# Altium schematic sheet Skeem1.SchDoc(Skeem1)
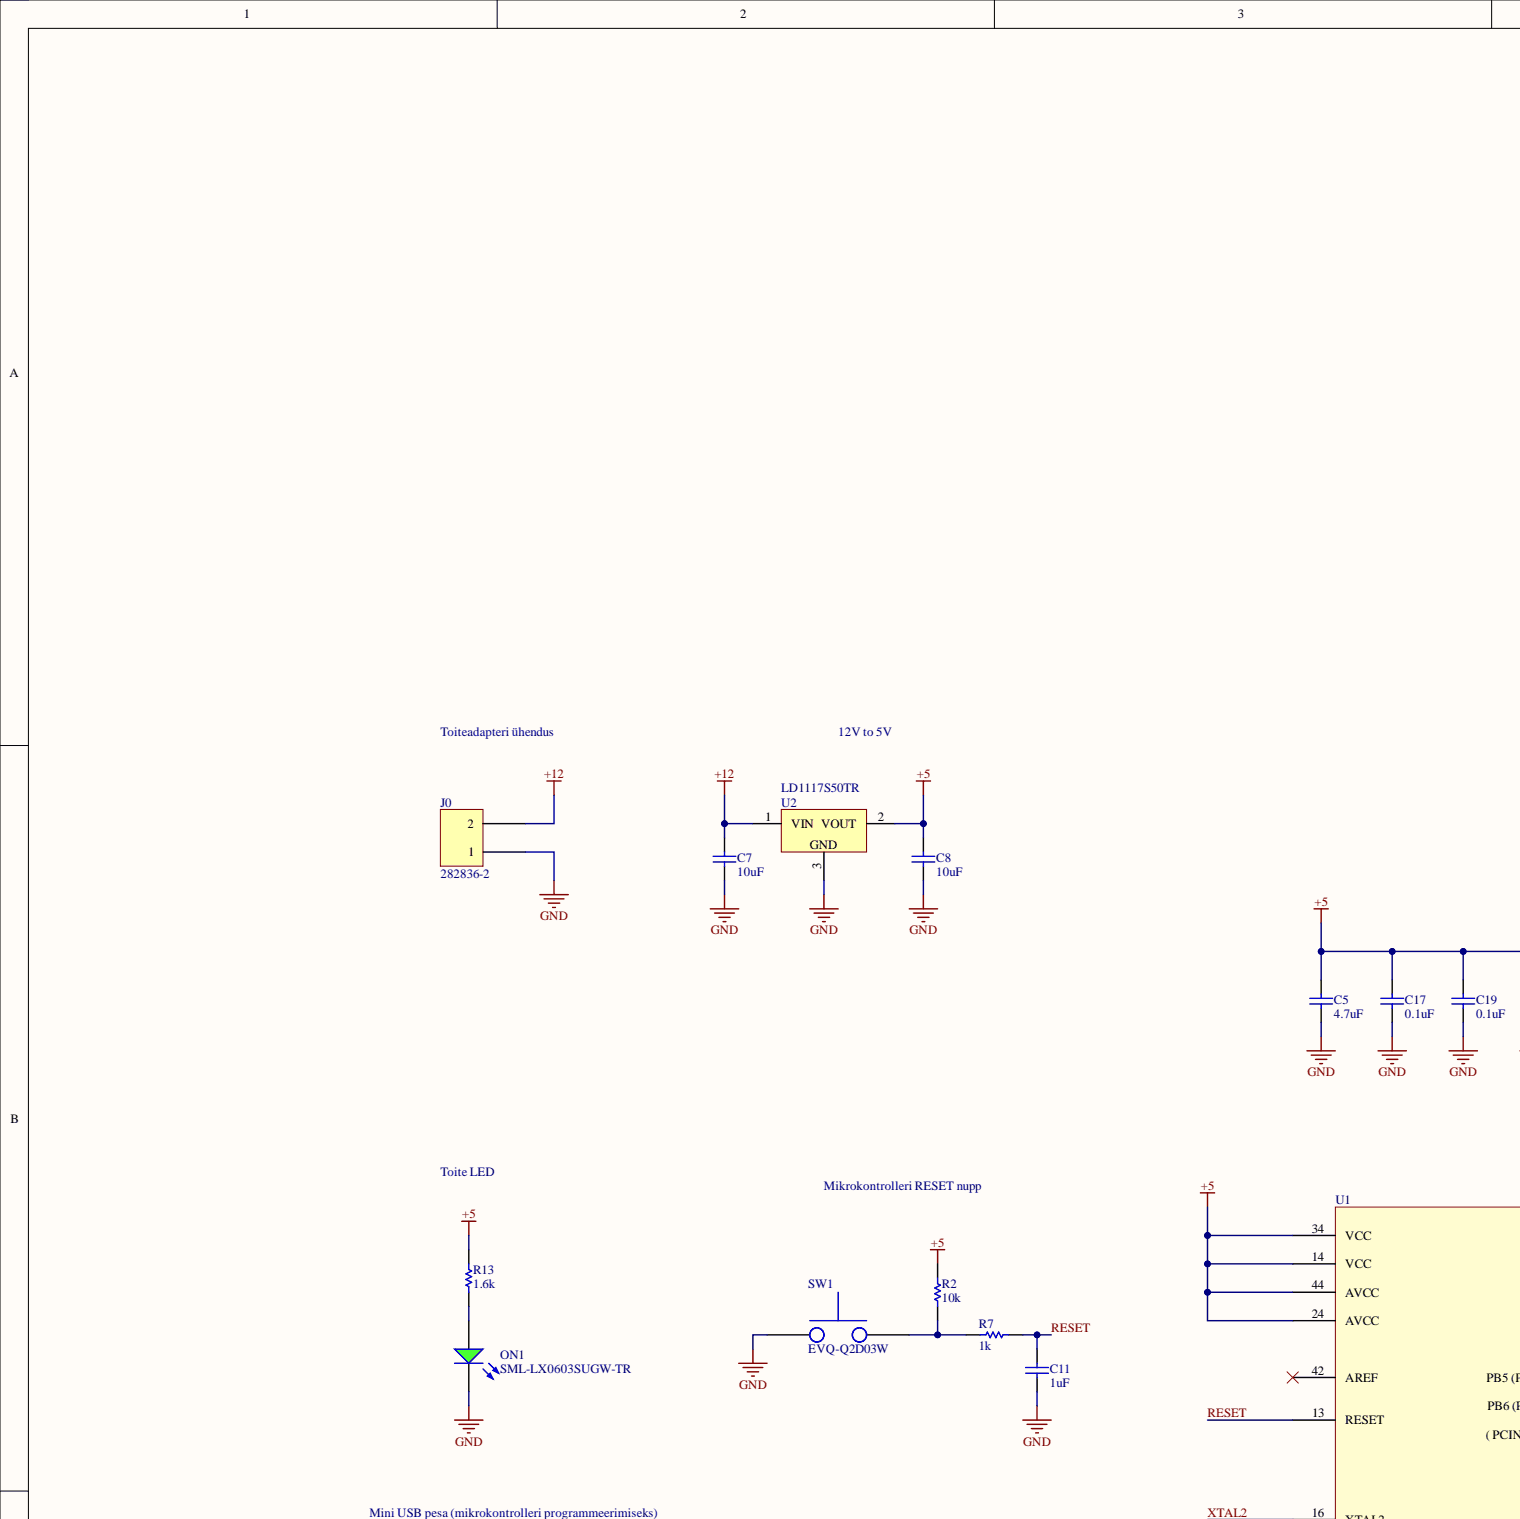
[diagram p0: Skeem1.SchDoc, top left]
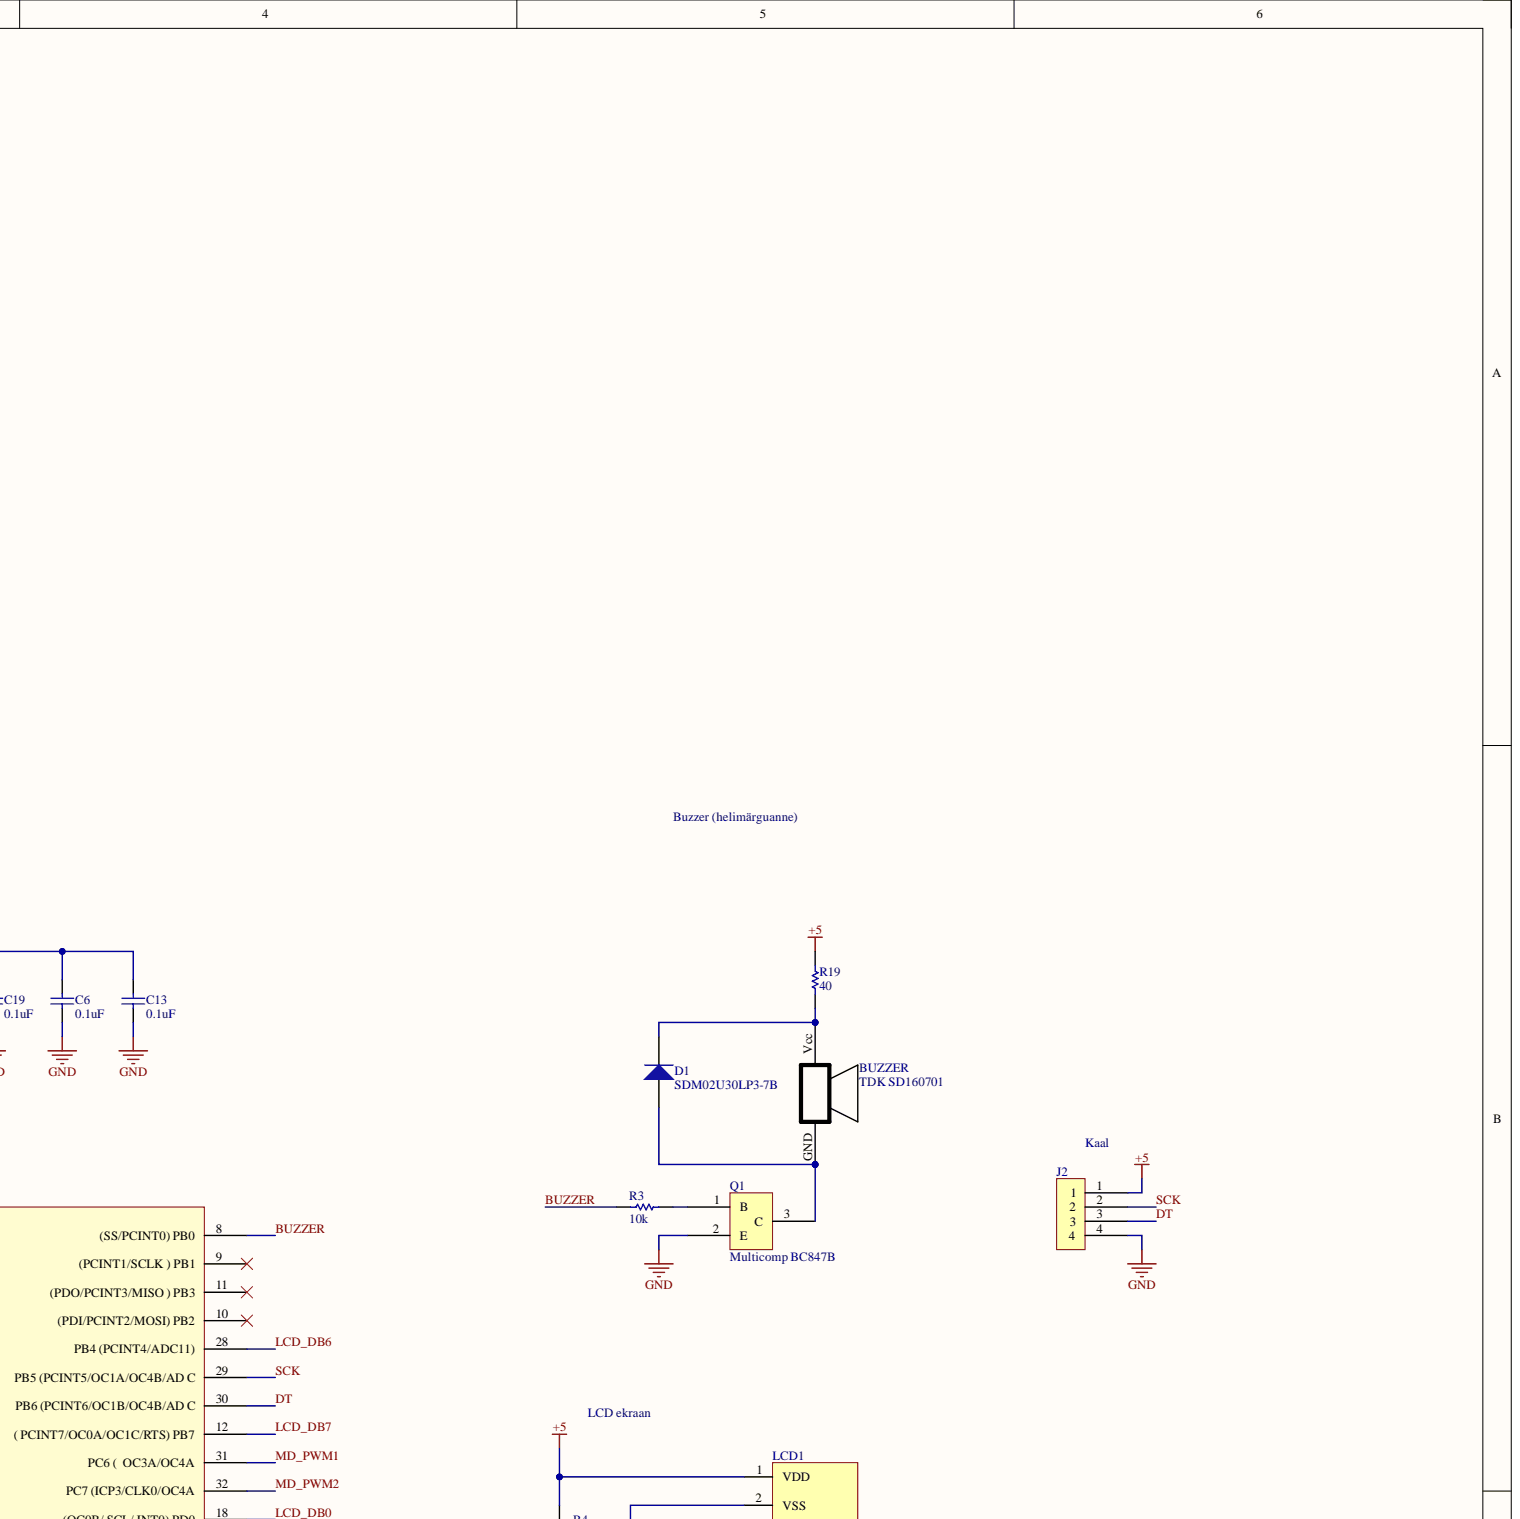
[diagram p1: Skeem1.SchDoc, top right]
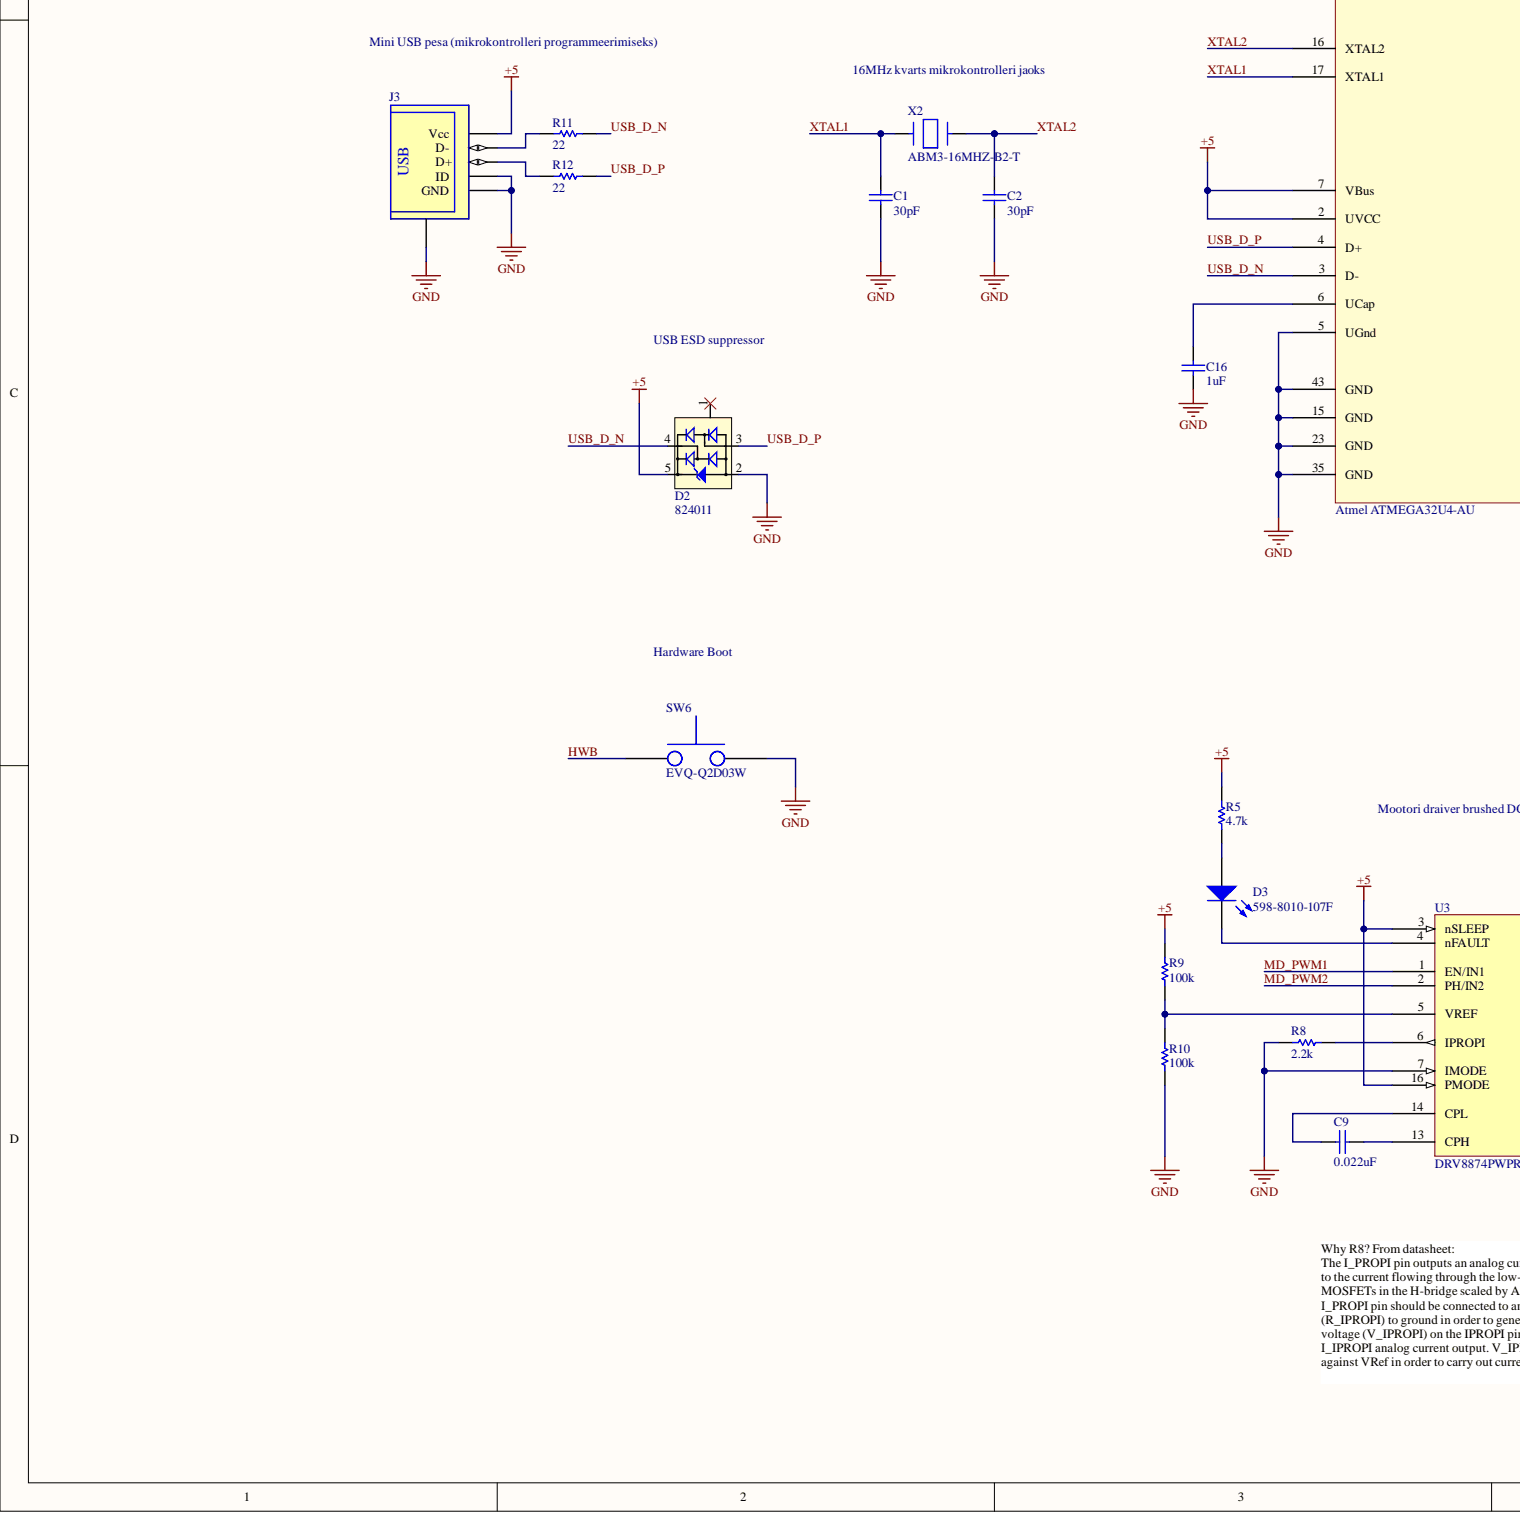
[diagram p2: Skeem1.SchDoc, bottom left]
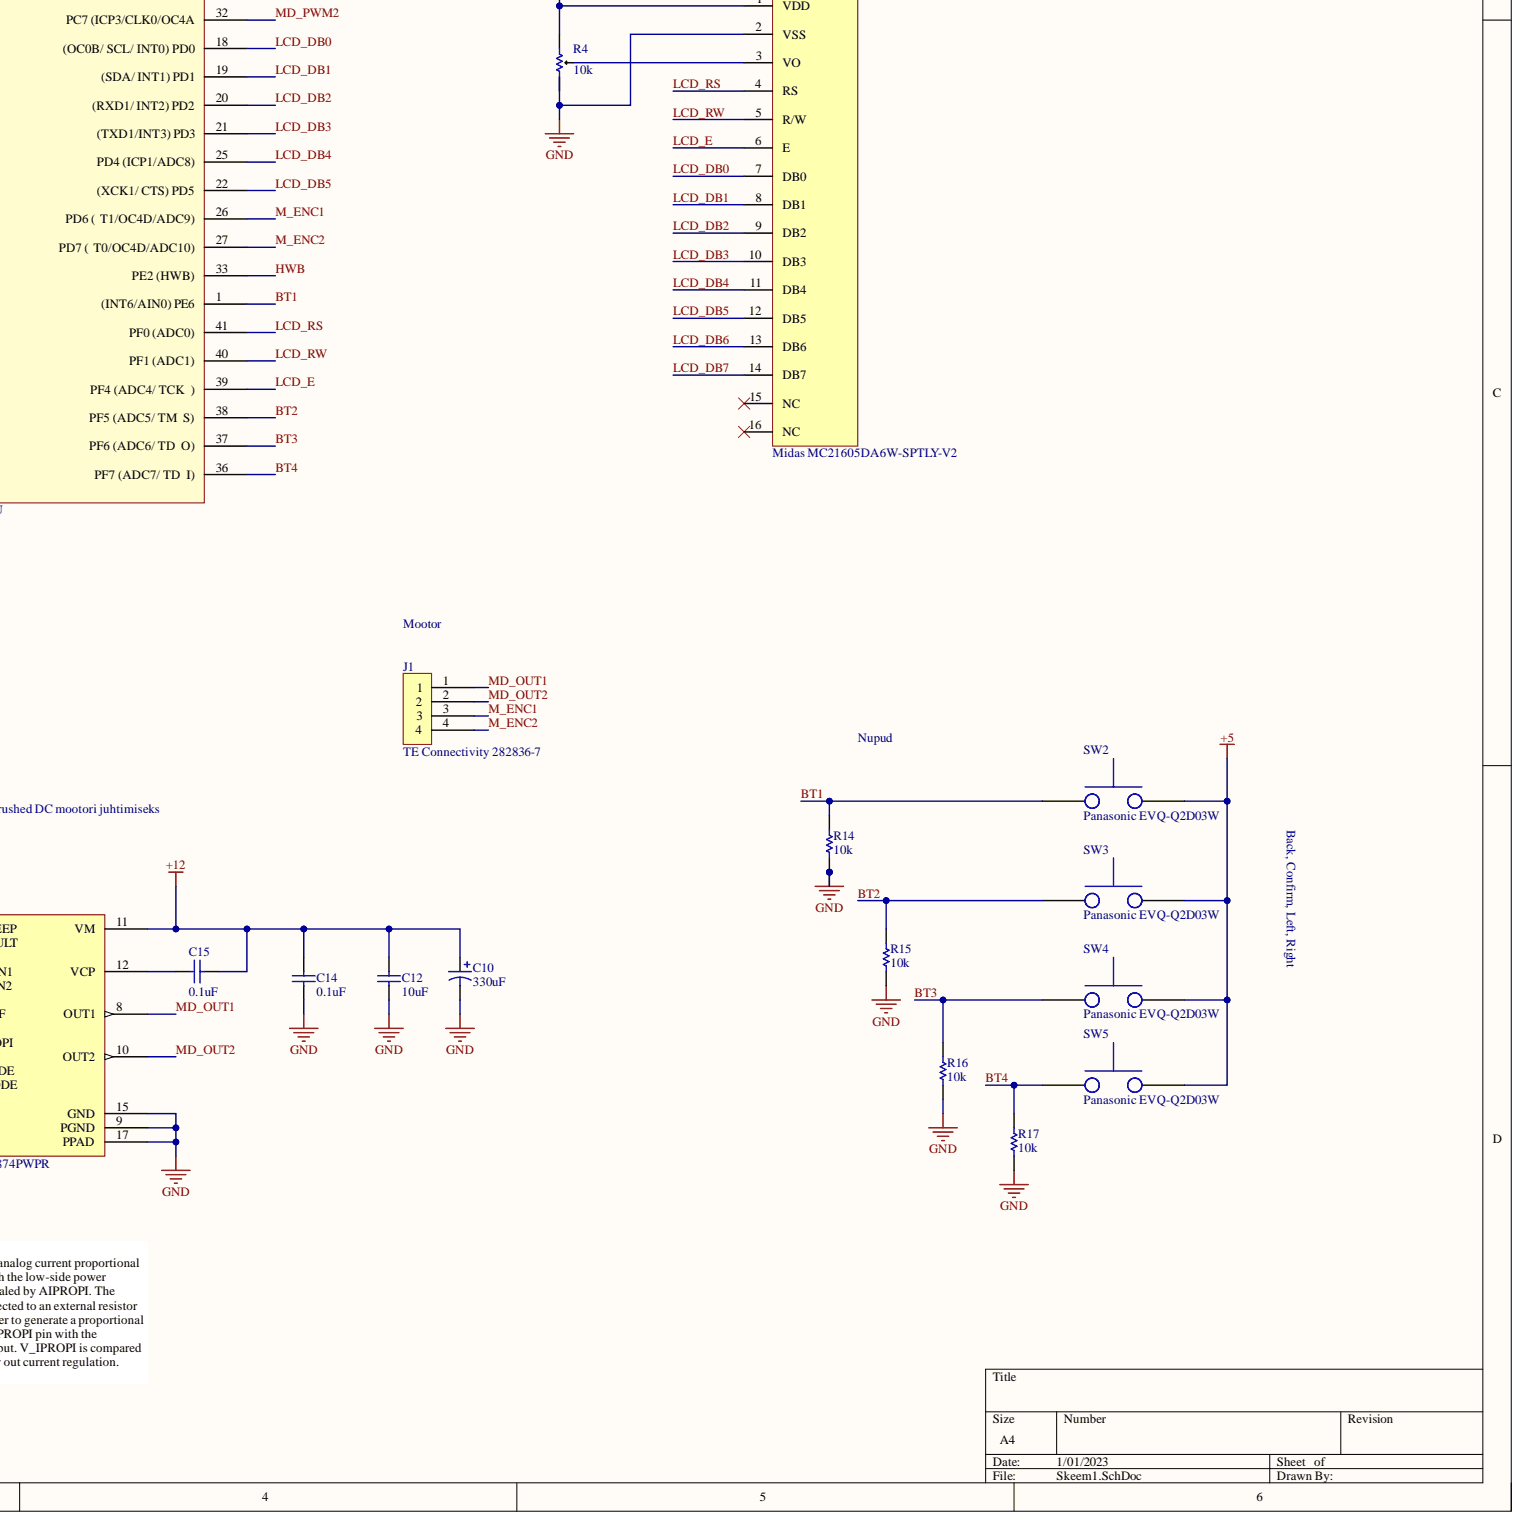
[diagram p3: Skeem1.SchDoc, bottom right]

## Parts
BUZZER  TDK SD160701  Manufacturer Part Number: SD160701
C1  30pF
C2  30pF
C5  4.7uF
C6  0.1uF  [PCB-0002-00062-3]
C7  10uF  [PCB-wvd42uoi0udsmgfukqhe-1]
C8  10uF  [PCB-wvd42uoi0udsmgfukqhe-1]
C9  0.022uF
C10  330uF  [PCB-8ijitsarb7x4oxse96cd-1]
C11  1uF  [PCB-zmhnirspvwu5lpu2azvo-1]
C12  10uF
C13  0.1uF  [PCB-0002-00062-3]
C14  0.1uF  [PCB-0002-00062-3]
C15  0.1uF  [PCB-0002-00062-3]
C16  1uF
C17  0.1uF  [PCB-0002-00062-3]
C19  0.1uF  [PCB-0002-00062-3]
D1  SDM02U30LP3-7B  [PCB-karmeiynjjwb0q118upo-1]
D2  824011
D3  598-8010-107F  [PCB-hueh31pu4k40hzp3j03i-1]
J0  282836-2
J1  TE Connectivity 282836-7  [PCB-3tvdnj0eouyeqqfalykp-1]
J2  TE Connectivity 282836-7  [PCB-3tvdnj0eouyeqqfalykp-1]
J3  MINI USB 2.0 TYPE B, RECEPTACLE, SMT (FCI 10033526-N3212MLF)  (value not drawn)
LCD1  Midas MC21605DA6W-SPTLY-V2  Manufacturer Part Number: MC21605DA6W-SPTLY-V2
ON1  SML-LX0603SUGW-TR  [PCB-0002-02151-1.A.4]
Q1  Multicomp BC847B  Manufacturer Part Number: BC847B  [PCB-3mljzpuay2uxg0ut9sma-1]
R2  10k  [PCB-0002-00066-3]
R3  10k  [PCB-0002-00066-3]
R4  10k  [PCB-obt52c5d0m95txgvi4qh-1]
R5  4.7k  [PCB-57p3ox399wggapangvi3-1]
R7  1k
R8  2.2k  [PCB-n8he3yyaps2a9usdbg2i-1]
R9  100k  [PCB-vit9mexsle3dy07yp330-1]
R10  100k  [PCB-vit9mexsle3dy07yp330-1]
R11  22
R12  22
R13  1.6k  [PCB-0002-00066-1]
R14  10k  [PCB-0002-00066-3]
R15  10k  [PCB-0002-00066-3]
R16  10k  [PCB-0002-00066-3]
R17  10k  [PCB-0002-00066-3]
R19  40
SW1  EVQ-Q2D03W  [PCB-5wv5hrqvibrfqodtaqn8-1]
SW2  Panasonic EVQ-Q2D03W  Manufacturer Part Number: EVQ-Q2D03W  [PCB-5wv5hrqvibrfqodtaqn8-1]
SW3  Panasonic EVQ-Q2D03W  Manufacturer Part Number: EVQ-Q2D03W  [PCB-5wv5hrqvibrfqodtaqn8-1]
SW4  Panasonic EVQ-Q2D03W  Manufacturer Part Number: EVQ-Q2D03W  [PCB-5wv5hrqvibrfqodtaqn8-1]
SW5  Panasonic EVQ-Q2D03W  Manufacturer Part Number: EVQ-Q2D03W  [PCB-5wv5hrqvibrfqodtaqn8-1]
SW6  EVQ-Q2D03W  [PCB-5wv5hrqvibrfqodtaqn8-1]
U1  Atmel ATMEGA32U4-AU  Manufacturer Part Number: ATMEGA32U4-AU
U2  LD1117S50TR  [PCB-0002-02299-1]
U3  DRV8874PWPR  [PCB-45171vetpwtrcknlr443-5]
X2  ABM3-16MHZ-B2-T

## Nets
+5: C5.2 C6.2 C8.2 C13.2 C17.2 C19.2 D2.5 J2.1 J3.1(Vcc) LCD1.1(VDD) R2.2 R4.3 R5.2 R9.1 R13.2 R19.2 SW2.1 SW3.1 SW4.1 SW5.1 U1.2(UVCC) U1.7(VBus) U1.14(VCC) U1.24(AVCC) U1.34(VCC) U1.44(AVCC) U2.2 U3.3(nSLEEP) U3.16(PMODE)
+12: C7.2 C10.1 C12.2 C14.2 C15.2 J0.2 U2.1 U3.11(VM)
GND: C1.1 C2.1 C5.1 C6.1 C7.1 C8.1 C10.2 C11.2 C12.1 C13.1 C14.1 C16.1 C17.1 C19.1 D2.2 J0.1 J2.4 J3.4(ID) J3.5(GND) J3.6 LCD1.2(VSS) ON1.C Q1.2 R4.1 R8.1 R10.2 R14.1 R15.1 R16.1 R17.1 SW1.2 SW6.1 U1.5(UGnd) U1.15(GND) U1.23(GND) U1.35(GND) U1.43(GND) U2.3 U3.7(IMODE) U3.9(PGND) U3.15(GND) U3.17(PPAD)
BT1: R14.2 SW2.2 U1.1((INT6/AIN0)_PE6)
BT2: R15.2 SW3.2 U1.38(S))
BT3: R16.2 SW4.2 U1.37(O))
BT4: R17.2 SW5.2 U1.36(I))
BUZZER: R3.1 U1.8((SS/PCINT0)_PB0)
DT: J2.3 U1.30(PB6_(PCINT6/OC1B/OC4B/AD_C)
HWB: SW6.2 U1.33(PE2_(HWB))
LCD_DB0: LCD1.7(DB0) U1.18((OC0B/_SCL/_INT0)_PD0)
LCD_DB1: LCD1.8(DB1) U1.19((SDA/_INT1)_PD1)
LCD_DB2: LCD1.9(DB2) U1.20((RXD1/_INT2)_PD2)
LCD_DB3: LCD1.10(DB3) U1.21((TXD1/INT3)_PD3)
LCD_DB4: LCD1.11(DB4) U1.25(PD4_(ICP1/ADC8))
LCD_DB5: LCD1.12(DB5) U1.22((XCK1/_CTS)_PD5)
LCD_DB6: LCD1.13(DB6) U1.28(PB4_(PCINT4/ADC11))
LCD_DB7: LCD1.14(DB7) U1.12((_PCINT7/OC0A/OC1C/RTS)_PB7)
LCD_E: LCD1.6(E) U1.39())
LCD_RS: LCD1.4(RS) U1.41(PF0_(ADC0))
LCD_RW: LCD1.5(R/W) U1.40(PF1_(ADC1))
M_ENC1: J1.3 U1.26(T1/OC4D/ADC9))
M_ENC2: J1.4 U1.27(T0/OC4D/ADC10))
MD_OUT1: J1.1 U3.8(OUT1)
MD_OUT2: J1.2 U3.10(OUT2)
MD_PWM1: U1.31(OC3A/OC4A) U3.1(EN/IN1)
MD_PWM2: U1.32(PC7_(ICP3/CLK0/OC4A) U3.2(PH/IN2)
RESET: C11.1 R7.2 U1.13(RESET)
SCK: J2.2 U1.29
USB_D_N: D2.4 R11.2 U1.3(D-)
USB_D_P: D2.3 R12.2 U1.4(D+)
XTAL1: C1.2 U1.17(XTAL1) X2.1
XTAL2: C2.2 U1.16(XTAL2) X2.2
NetBUZZER_GND: BUZZER.GND D1.1 Q1.3
NetBUZZER_Vcc: BUZZER.Vcc D1.2 R19.1
NetC9_1: C9.1 U3.14(CPL)
NetC9_2: C9.2 U3.13(CPH)
NetC15_1: C15.1 U3.12(VCP)
NetC16_2: C16.2 U1.6(UCap)
NetD3_A: D3.A R5.1
NetD3_K: D3.K U3.4(nFAULT)
NetJ3_2: J3.2(D-) R11.1
NetJ3_3: J3.3(D+) R12.1
NetLCD1_3: LCD1.3(VO) R4.2
NetON1_A: ON1.A R13.1
NetQ1_1: Q1.1 R3.2
NetR2_1: R2.1 R7.1 SW1.1
NetR8_2: R8.2 U3.6(IPROPI)
NetR9_2: R9.2 R10.1 U3.5(VREF)

Not connected: D2 1; LCD1 15(NC) 16(NC); U1 9((PCINT1/SCLK_)_PB1) 10((PDI/PCINT2/MOSI)_PB2) 11((PDO/PCINT3/MISO_)_PB3) 42(AREF)

## Library data of the parts
BUZZER = CMP-a5a96caf94997a6e-5: Audio Buzzer Electromagnetic 1Vo-p 5Vo-p 60mA 3Vo-p 80dBA Through Hole Pin Tray; Lead-Free Status: Lead Free; ReplaceComponentId: HENOFNDV; Resonant Frequency: 4.10 kHz; Voltage Rating (DC): 5.00 V; Component Kind: Standard; Library Name: My Personal Space; Mounting Style: Through Hole; Octopart Url: https://octopart.com/sd160701-tdk-791638; OctopartID: a5a96caf94997a6e; Packaging: Tray; PartId: 1; RoHS: Compliant
C1 C2 = CMP-3554665-2: Multilayer Ceramic Capacitors MLCC - SMD/SMT 0603 30pF 250V C0G 5% HI FREQ; Manufacturer Part Number: VJ0603D300JXPAJ; Capacitance: 3e-11 F; Dielectric Characteristic: C0G/NP0; Lead-Free Status: Lead Free; Voltage Rating (DC): 250.0 V; Capacitance Tolerance: ±5%; Component Kind: Standard; Library Name: My Personal Space; Octopart Url: https://octopart.com/vj0603d300jxpaj-vishay-45210262; OctopartID: a54f6ef45abf7a88; Packaging: Cut Tape (CT); RoHS: Compliant
C5 = CMP-4caf8516eb679368-5: CL10 Series 4.7 uF 10 V ±10 % Tolerance X5R SMT Multilayer Ceramic Capacitor; Manufacturer Part Number: CL10A475KP8NNNC; Capacitance: 4.7e-06 F; Capacitance Tolerance: ±10%; Lead-Free Status: Lead Free; Voltage Rating (DC): 10.0 V; Case/Package: 0603; Component Kind: Standard; Dielectric Characteristic: X5R; Library Name: My Personal Space; Mounting Style: Surface Mount; Octopart URL: http://octopart.com/cl10a475kp8nnnc-samsung-11764398; OctopartID: 4caf8516eb679368; RoHS: Compliant
C6 C13-C15 C17 C19 = CMP-3016f860c6a7efd1-1: CL10 Series 0.1 uF 100 V ±10 % Tolerance X7R SMT Multilayer Ceramic Capacitor; Manufacturer Part Number: CL10B104KC8NNNC; Capacitance: 100 nF; Capacitance Tolerance: ±10%; Lead-Free Status: Lead Free; Voltage Rating (DC): 100 V; Case/Package: 0603; Component Kind: Standard; Dielectric Characteristic: X7R; Library Name: My Personal Space; Octopart URL: http://octopart.com/cl10b104kc8nnnc-samsung-24957911; OctopartID: 3016f860c6a7efd1; RoHS: Compliant
C7 C8 = CMP-121611-5: C Series 0603 10 uF 25 V ±10 % Tolerance X5R Multilayer Ceramic Capacitor; Manufacturer: Murata; Part Number: GRM188R61E106KA73J; Ex Price: 0.36; Tolerance: 10%; VN Price: 850; Voltage/Wattage: 25V; Component Kind: Standard; Ex Supplier: https://www.mouser.vn/ProductDetail/Murata-Electronics/GRM188R61E106KA73J?qs=sGAEpiMZZMukHu%252BjC5l7YUSokBdqpA2iyRK88YQudCM%3D; Library Name: My Personal Space; OctopartID: a02668a768b37fe1; Package: 0603; Value: 10uF; VN Supplier: https://www.thegioiic.com/products/tu-gom-0603-10uf-25v
C9 = CMP-3551317-2: Multilayer Ceramic Capacitors MLCC - SMD/SMT 0603 0.022uF 50volts X7R 10%; Manufacturer Part Number: VJ0603Y223KXACW1BC; Capacitance: 2.2e-08 F; Dielectric Material: Tantalum; Lead-Free Status: Lead Free; Voltage Rating (DC): 50.0 V; Capacitance Tolerance: ±10%; Case/Package: 0603; Component Kind: Standard; Dielectric Characteristic: X7R; Library Name: My Personal Space; Number of Pins: 2; Octopart Url: https://octopart.com/vj0603y223kxacw1bc-vishay-42059160; OctopartID: ac2c67a74cd273eb; RoHS: Compliant
C10 = CMP-05cfc83f62e3da08-3: Cap Aluminum 330uF 35V 20% Radial Aluminum Cylindrical Can 3.5mm 1240mA 8000 hr 105°C Ammo Pack; Manufacturer Part Number: EEUFS1V331LB; Capacitance: 330 µF; Voltage Rating (DC): 35.0 V; Capacitance Tolerance: ±20%; Component Kind: Standard; Library Name: My Personal Space; Lifecycle Status: Not Listed by Manufacturer; Octopart URL: https://octopart.com/eeufs1v331lb-panasonic-95540968; OctopartID: 44a6881db7289b61; Operating Temperature: -40.0 °C (min); RoHS: Compliant; Size-Diameter: 8.00 mm; Size-Height: 15.0 mm
C11 = CMP-2511764-3: Ceramic Multilayer Capacitor; Capacitor Type: General Purpose; Capacitance: 1µF; Capacitance Tolerance: ± 10%;...; Manufacturer Part Number: C0603C105K8PACTU; Capacitance: 1.00 µF; Case/Package (SI): 1608; Dielectric Characteristic: X5R; Lead-Free Status: Lead Free; Voltage Rating (DC): 10.0 V; Capacitance Tolerance: ±10%; Case/Package: 0603; Component Kind: Standard; Dielectric Material: Ceramic; Library Name: My Personal Space; Mounting Style: Surface Mount; Number of Pins: 2; Octopart URL: https://octopart.com/c0603c105k8pactu-kemet-129917; OctopartID: 3b5ef255e545e499; Operating Temperature: -55.0 °C to 85.0 °C; Packaging: Cut Tape (CT), Reel, Tape & Reel (TR); REACH SVHC Compliance: No SVHC; RoHS: Compliant; Size-Height: 800 µm; Size-Length: 1.60 mm; Size-Width: 800 µm
C12 = CMP-2511175-2: Capacitor 1206 10uF 25V; Manufacturer Part Number: C1206C106K3PACTU; Capacitance: 1e-05 F; Capacitance Tolerance: ±10%; Dielectric Characteristic: X5R; Dielectric Material: Ceramic; Lead-Free Status: Lead Free; Voltage Rating (DC): 25.0 V; Case/Package: 1206; Component Kind: Standard; Library Name: My Personal Space; Mounting Style: Surface Mount; Octopart Url: https://octopart.com/c1206c106k3pac7800-kemet-1770885; OctopartID: 1a91d3803a72c556; RoHS: Compliant
C16 = CMP-334bf90c36c3ec8c-4: Capacitor; Ceramic; Cap 1 uF; Tol 10%; Vol-Rtg 16 VDC; SMT; 1206; X7R; Tape & Reel; Manufacturer Part Number: 1206YC105KAT2A; Capacitance: 1.00 µF; Case/Package (SI): 3216; Insulation Resistance: 100 GΩ; Lead-Free Status: Lead Free; Voltage Rating (DC): 16.0 V; Capacitance Tolerance: ±10%; Case/Package: 1206; Component Kind: Standard; Dielectric Characteristic: X7R; Library Name: My Personal Space; Mounting Style: Surface Mount; Number of Pins: 2; Octopart URL: http://octopart.com/1206yc105kat2a-avx-55386350; OctopartID: 334bf90c36c3ec8c; Packaging: Cut Tape (CT), Tape & Reel (TR); REACH SVHC Compliance: No SVHC; RoHS: Compliant; Size-Height: 1.27 mm; Size-Length: 3.20 mm; Size-Thickness: 1.27 mm; Size-Width: 1.60 mm
D1 = CMP-0ea9c6d89cead16e-2: Diode Schottky 30V 0.1A Automotive 2-Pin X3-DFN T/R; Lead-Free Status: Lead Free; Component Kind: Standard; Library Name: My Personal Space; Lifecycle Status: Active; Manufacturer Part Number: SDM02U30LP3-7B; Mounting Style: Surface Mount; Octopart URL: http://octopart.com/sdm02u30lp3-7b-diodes+inc.-24885029; OctopartID: 0ea9c6d89cead16e; Packaging: Tape & Reel (TR); REACH SVHC Compliance: No SVHC; RoHS: Compliant
D2 = CMP-1038097-2: TVS Diode WE-TVS-HS, VRWM=5V; Breakdown Voltage: 6.1 V; Operating Voltage: 6.0 V; Component Kind: Standard; Library Name: My Personal Space; Manufacturer Part Number: 824011; Mounting Style: Surface Mount; Number of Pins: 5; Octopart Url: https://octopart.com/824011-w%C3%BCrth+elektronik-11914007; OctopartID: fa0533ba147425c2; Packaging: Cut Tape (CT); REACH SVHC Compliance: No SVHC; RoHS: Compliant
D3 = CMP-1544913-17: 598 Series 0603 - 1.6 x 0.8 mm Red 140° 40 mcd Surface Mount Micro LED; Component Kind: Standard; Library Name: My Personal Space
J0 = CMP-369bfdc835bbe95c-39: Wire to Board 2 Position 5 mm Pitch 30-16 AWG Euro Style Terminal Block; Current Rating: 13.5 A; ELV Compliant: Compliant; Lead-Free Status: Lead Free; Number of Contacts: 2; Pin Pitch: 0.005 m; Voltage Rating (AC): 250.0 V; Wire Gauge: 15.0 AWG; Color: Green; Component Kind: Standard; Contact Material: Brass; Contact Plating: Tin; Flammability Rating: UL 94 V-0; Housing Color: Green; Housing Material: Nylon 6/6; Insulation Material: Nylon; Library Name: My Personal Space; Lifecycle Status: Active; Manufacturer Part Number: 282836-2; Mounting Style: PCB; Number of Positions: 2; Octopart URL: http://octopart.com/282836-2-te+connectivity-39396934; OctopartID: 369bfdc835bbe95c; Orientation: Right Angle; REACH SVHC Compliance: No SVHC; RoHS: Compliant
J1 J2 = CMP-0e95c5c61ea4d152-10: Connector, Terminal Block; Wire Receptacle; 4 Pos.; 16-30 AWG; 0.197 in. CL; Sid; Manufacturer Part Number: 282836-4; Current Rating: 13.5 A; ELV Compliant: Compliant; Lead-Free Status: Lead Free; Number of Contacts: 4; Pin Pitch: 5.00 mm; Voltage Rating (AC): 250 V; Wire Gauge: 15.0 AWG; Color: Green; Component Kind: Standard; Contact Material: Brass; Contact Plating: Tin; Flammability Rating: UL 94 V-0; Housing Color: Green; Housing Material: Nylon 6/6; Insulation Material: Nylon; Library Name: My Personal Space; Lifecycle Status: Active; Mounting Style: Through Hole, PC Board, Screw, PCB; Number of Positions: 4; Octopart URL: https://octopart.com/282836-4-te+connectivity-46505329; OctopartID: 294fe2809158f688; Operating Temperature: -40.0 °C to 110 °C; Orientation: Right Angle; Packaging: Bulk; REACH SVHC Compliance: No SVHC; RoHS: Compliant
J3 = CMP-942470-7: FCI   10033526-N3212MLF   MINI USB 2.0 TYPE B, RECEPTACLE, SMT; Gender: Female; Number of Contacts: 5; Component Kind: Standard; Contact Material: Copper Alloy; Contact Plating: Gold; Library Name: My Personal Space; Manufacturer Part Number: 10033526-N3212MLF; Mounting Style: Surface Mount; Octopart Url: https://octopart.com/10033526-n3212mlf-fci-40393223; OctopartID: 16abddc969cac96c; Orientation: Right Angle; REACH SVHC Compliance: No SVHC; RoHS: Compliant
LCD1 = CMP-8a0e4792bf6c55c9-8: Display, Alphanumeric, 16X2, Yellow/grn Rohs Compliant: Yes; Character Size-Height: 5.23 mm; Component Kind: Standard; Library Name: My Personal Space; Octopart Url: https://octopart.com/mc21605da6w-sptly-v2-midas-76943364; OctopartID: 8a0e4792bf6c55c9; Operating Temperature: 70.0 °C (max); RoHS: Compliant
ON1 = CMP-65d2acda0cfcba15-1.A.3: Super Ultra Green 0603 1.6 x 0.8 mm 140° Diffused 45 mcd 2.2 V PCB Chip SMT LED; Forward Voltage: 2.20 V; Lead-Free Status: Lead Free; Luminous Intensity: 45.0 mcd; Wavelength: 574 nm; Case/Package: 0603; Color: Green; Component Kind: Standard; Lens Type: Diffused; Library Name: My Personal Space; Manufacturer Part Number: SML-LX0603SUGW-TR; Mounting Style: Surface Mount, Vertical; Number of Pins: 2; Octopart Url: http://octopart.com/sml-lx0603sugw-tr-lumex-150600; OctopartID: 65d2acda0cfcba15; Packaging: Cut Tape (CT), Tape & Reel (TR); RoHS: Compliant; Seller Landing  Url: https://octopart-clicks.com/click/track?country=US&ak=8157f166&sig=056782a&sid=459&ppid=150600&vpid=1262106&ct=offers; Size-Width: 1.60 mm; Viewing Angle: 140 °
Q1 = CMP-ec1d256d0eef33da-3: TRANSISTOR, NPN, SOT-23; Transistor Type: Bipolar; Transistor Polarity: NPN; Collector-to-Emitter Breakdown Voltage: ...; Power Dissipation: 250 mW; Case/Package: TO-236, SC-59, SOT-23-3; Component Kind: Standard; Library Name: My Personal Space; Mounting Style: Surface Mount; Number of Pins: 3; Octopart URL: http://octopart.com/bc847b-multicomp-8046484; OctopartID: ec1d256d0eef33da; Packaging: Roll, Tape; Polarity: NPN; REACH SVHC Compliance: No SVHC; RoHS: Compliant
R2 R3 R14-R17 = CMP-c4650f0d5cc11ba2-27: Res General Purpose Thick Film 0603 10K Ohm 1% 1/10W ±100ppm/°C Molded SMD Paper T/R; Manufacturer Part Number: RC0603FR-0710KL; Case/Package (SI): 1608; Lead-Free Status: Lead Free; Power Rating: 100 mW; Resistance: 10.0 kΩ; Temperature Coefficient: -100 ppm/°C to 100 ppm/°C; Voltage Rating (DC): 75.0 V, 50.0 V; Case/Package: 0603; Component Kind: Standard; Library Name: My Personal Space; Mounting Style: Surface Mount; Number of Pins: 2; Octopart URL: https://octopart.com/rc0603fr-0710kl-yageo-40025538; OctopartID: c4650f0d5cc11ba2; Operating Temperature: 155 °C (max); Packaging: Tape & Reel; REACH SVHC Compliance: No SVHC; Resistance Tolerance: ±1%; RoHS: Compliant; Size-Height: 450 µm; Size-Length: 1.60 mm; Size-Width: 800 µm
R4 = CMP-1577701-11: Res Conductive Plastic POT 10K Ohm 20% 3/100W 1Turn 6mm Pin Bracket Mount/Through Hole; Manufacturer Part Number: P090L-02F25BR10K; Lead-Free Status: Lead Free; Power Rating: 30.0 mW; Component Kind: Standard; Library Name: My Personal Space; Mounting Style: Through Hole, Board, PCB; Octopart URL: https://octopart.com/p090l-02f25br10k-bi+technologies-5427413; OctopartID: 7c74b52c89c7a3b3; Operating Temperature: 70.0 °C (max); Packaging: Tray; Resistance Tolerance: ±20%; RoHS: Compliant
R5 = CMP-3ca7f596181de360-11: Res Thick Film 0603 4.7K Ohm 1% 1/10W ±100ppm/°C Molded SMD SMD Paper Tape on Plastic Reel; Manufacturer: Bourns Inc.; Manufacturer Part Number: CR0603-FX-4701ELF; Case/Package (SI): 1608; Power Rating: 100 mW; Resistance: 4.70 kΩ; Temperature Coefficient: -100 ppm/°C to 100 ppm/°C; Voltage Rating (DC): 50.0 V; Case/Package: 0603; Component Kind: Standard; Library Name: My Personal Space; Mounting Style: Surface Mount; Number of Pins: 2; Octopart URL: https://octopart.com/cr0603-fx-4701elf-bourns-3867100; OctopartID: 3ca7f596181de360; Packaging: Tape & Reel (TR), Cut Tape (CT); REACH SVHC Compliance: No SVHC; Resistance Tolerance: ±1%; RoHS: Compliant; Size-Length: 1.60 mm; Size-Thickness: 457 µm; Size-Width: 787 µm
R7 = CMP-667fac7b8c3ab9b8-1: Res Thick Film 0603 1K Ohm 1% 0.25W(1/4W) ±100ppm/C Pad SMD Automotive T/R; Manufacturer Part Number: ERJ-PA3F1001V; Case/Package (SI): 1608; Lead-Free Status: Lead Free; Power Rating: 250 mW; Resistance: 1.00 kΩ; Temperature Coefficient: -100 ppm/°C to 100 ppm/°C; Voltage Rating (DC): 150 V; Case/Package: 1608, 0603; Component Kind: Standard; Library Name: My Personal Space; Lifecycle Status: Not Listed by Manufacturer; Octopart Url: https://octopart.com/erj-pa3f1001v-panasonic-51302179; OctopartID: 667fac7b8c3ab9b8; Packaging: Cut Tape (CT), Tape & Reel (TR); REACH SVHC Compliance: No SVHC; Resistance Tolerance: ±1%; RoHS: Compliant
R8 = CMP-91845a29de804e43-1: Res Thin Film 0603 2.2K Ohm 1% 0.1W(1/10W) }50ppm/ Molded SMD Automotive Medical T/R; Manufacturer Part Number: MCT06030C2201FP500; Case/Package (SI): 1608; Lead-Free Status: Lead Free; Power Rating: 125 mW; Resistance: 2.20 kΩ; Voltage Rating (DC): 75.0 V; Case/Package: 0603, 1608; Component Kind: Standard; Library Name: My Personal Space; Octopart URL: http://octopart.com/mct06030c2201fp500-vishay-44526178; OctopartID: 91845a29de804e43; Packaging: Cut Tape (CT); Resistance Tolerance: ±1%; RoHS: Compliant; Size-Height: 450 µm; Size-Length: 1.55 mm; Size-Width: 850 µm
R9 R10 = CMP-fb34331a5cbc24f3-1: Res Thick Film 0603 100K Ohm 1% 0.25W(1/4W) Â±100ppm/C Pad SMD Automotive T/R; Manufacturer Part Number: ESR03EZPF1003; Component Kind: Standard; Library Name: My Personal Space; Octopart URL: https://octopart.com/esr03ezpf1003-rohm-19170030; OctopartID: fb34331a5cbc24f3; RoHS: Compliant
R11 R12 = CMP-12519960-2: PANASONIC   ERJPA3F22R0V   RESISTOR, ERJP, 22 OHM, 0603, 0.25W, 1%; Resistance: 22.0 Ω; Voltage Rating (DC): 150.0 V; Component Kind: Standard; Library Name: My Personal Space; Lifecycle Status: Not Listed by Manufacturer; Manufacturer Part Number: ERJPA3F22R0V; Octopart Url: https://octopart.com/erjpa3f22r0v-panasonic-30042281; OctopartID: 0edac61a4315d11d; Packaging: Cut Tape (CT); Power Rating: 0.25 W; REACH SVHC Compliance: No SVHC; Resistance Tolerance: ±1%; RoHS: Compliant
R13 = CMP-3815f252b74ce7d2-1: Res Thick Film 0603 1.6K Ohm 1% 1/10W +/-100ppm/ßC Molded SMD Punched Carrier T/R; Manufacturer Part Number: ERJ-3EKF1601V; Case/Package (SI): 1608; Lead-Free Status: Lead Free; Power Rating: 100 mW; Resistance: 1.60 kΩ; Temperature Coefficient: -100 ppm/°C to 100 ppm/°C; Voltage Rating (DC): 75.0 V; Case/Package: 0603; Component Kind: Standard; Digi-Key Part Number: P1.60KHCT-ND; Library Name: My Personal Space; Mounting Style: Surface Mount; Octopart URL: https://octopart.com/erj-3ekf1601v-panasonic-55421503; OctopartID: 3815f252b74ce7d2; Packaging: Cut Tape (CT), Tape & Reel (TR); REACH SVHC Compliance: No SVHC; Resistance Tolerance: ±1%; RoHS: Compliant
R19 = CMP-3653042-2: Thick Film Resistors - SMD 0603 40.2ohms 1% Tol; Manufacturer Part Number: ERJ-3EKF40R2V; Lead-Free Status: Lead Free; Power Rating: 0.1 W; Resistance: 40.2 Ω; Voltage Rating (DC): 75.0 V; Case/Package: 0603; Component Kind: Standard; Library Name: My Personal Space; Lifecycle Status: Not Listed by Manufacturer; Mounting Style: Surface Mount; Octopart Url: https://octopart.com/erj-3ekf40r2v-panasonic-55421745; OctopartID: 59569311d7018691; Packaging: Cut Tape (CT); REACH SVHC Compliance: No SVHC; Resistance Tolerance: ±1%; RoHS: Compliant; Size-Height: 0.00045 m; Size-Length: 0.0016 m; Size-Width: 0.0008 m
SW1-SW6 = CMP-86b14fa8c5095976-4: Switch Tactile ON OFF SPST Round Button J-Bend 0.02A 15VDC 0.5N SMD Embossed T/R; Actuator Type: Standard; Contact Current Rating: 20.0 mA; Contact Resistance: 100 mΩ (max); Contacts Type: SPST-NO; Lead-Free Status: Lead Free; Operating Force: 500 m; Color: White; Component Kind: Standard; Library Name: My Personal Space; Lifecycle Status: Not Listed by Manufacturer; Mounting Style: Surface Mount; Octopart URL: https://octopart.com/evq-q2d03w-panasonic-1271518; OctopartID: 86b14fa8c5095976; Operating Temperature: 70.0 °C (max); Orientation: Vertical; REACH SVHC Compliance: No SVHC; RoHS: Compliant; Size-Height: 3.10 mm; Size-Length: 6.50 mm; Size-Thickness: 1.80 mm; Size-Width: 6.00 mm
  SW1 SW6: Manufacturer Part Number: EVQ-Q2D03W
U1 = CMP-ac4c65d93a75738b-18: ATmega Series 16 MHz 32 KB Flash 2.5 KB SRAM 8-Bit Microcontroller - TQFP-44; Clock Speed: 16.0 MHz; FLASH Memory Size: 32768 B; Lead-Free Status: Lead Free; RAM Memory Size: 2560 B; Supply Voltage (DC): 2.70 V (min); Component Kind: Standard; Library Name: My Personal Space; Mounting Style: Surface Mount; Number of Pins: 44; Octopart URL: http://octopart.com/atmega32u4-au-atmel-10466143; OctopartID: ac4c65d93a75738b; REACH SVHC Compliance: No SVHC; RoHS: Compliant
U2 = CMP-1170395-11: Low Drop Out (LDO) Regulator Position 5 Volt 1.3A 4-Pin(3+Tab) SOT-223; Dropout Voltage: 1.10 V; Input Voltage (DC): 15.0 V (max); Lead-Free Status: Lead Free; Number of Outputs: 1; Output Current: 800 mA, 950 mA; Output Voltage: 5.00 V (max); Case/Package: SOT-223; Component Kind: Standard; Library Name: My Personal Space; Lifecycle Status: Active; Manufacturer Part Number: LD1117S50TR; Mounting Style: Surface Mount; Number of Pins: 3; Octopart URL: https://octopart.com/ld1117s50tr-stmicroelectronics-529395; OctopartID: 1ca3d5a12a36c364; Operating Temperature: 150 °C (max); Packaging: Cut Tape (CT), Tape & Reel (TR); REACH SVHC Compliance: No SVHC; RoHS: Compliant; Supply Voltage (DC): 15.0 V (max)
U3 = CMP-1fd4d0c2f6c9c013-7: 38-V, 6-A H-bridge motor driver with integrated current sensing & feedback 16-HTSSOP -40 to 125; Component Kind: Standard; Library Name: My Personal Space
X2 = CMP-2a5ce356d707f59b-4: Crystal 16MHz ±20ppm (Tol) ±50ppm (Stability) 18pF; Component Kind: Standard; Library Name: My Personal Space
